# Altium schematic sheet CNCMicaMini.SchDoc
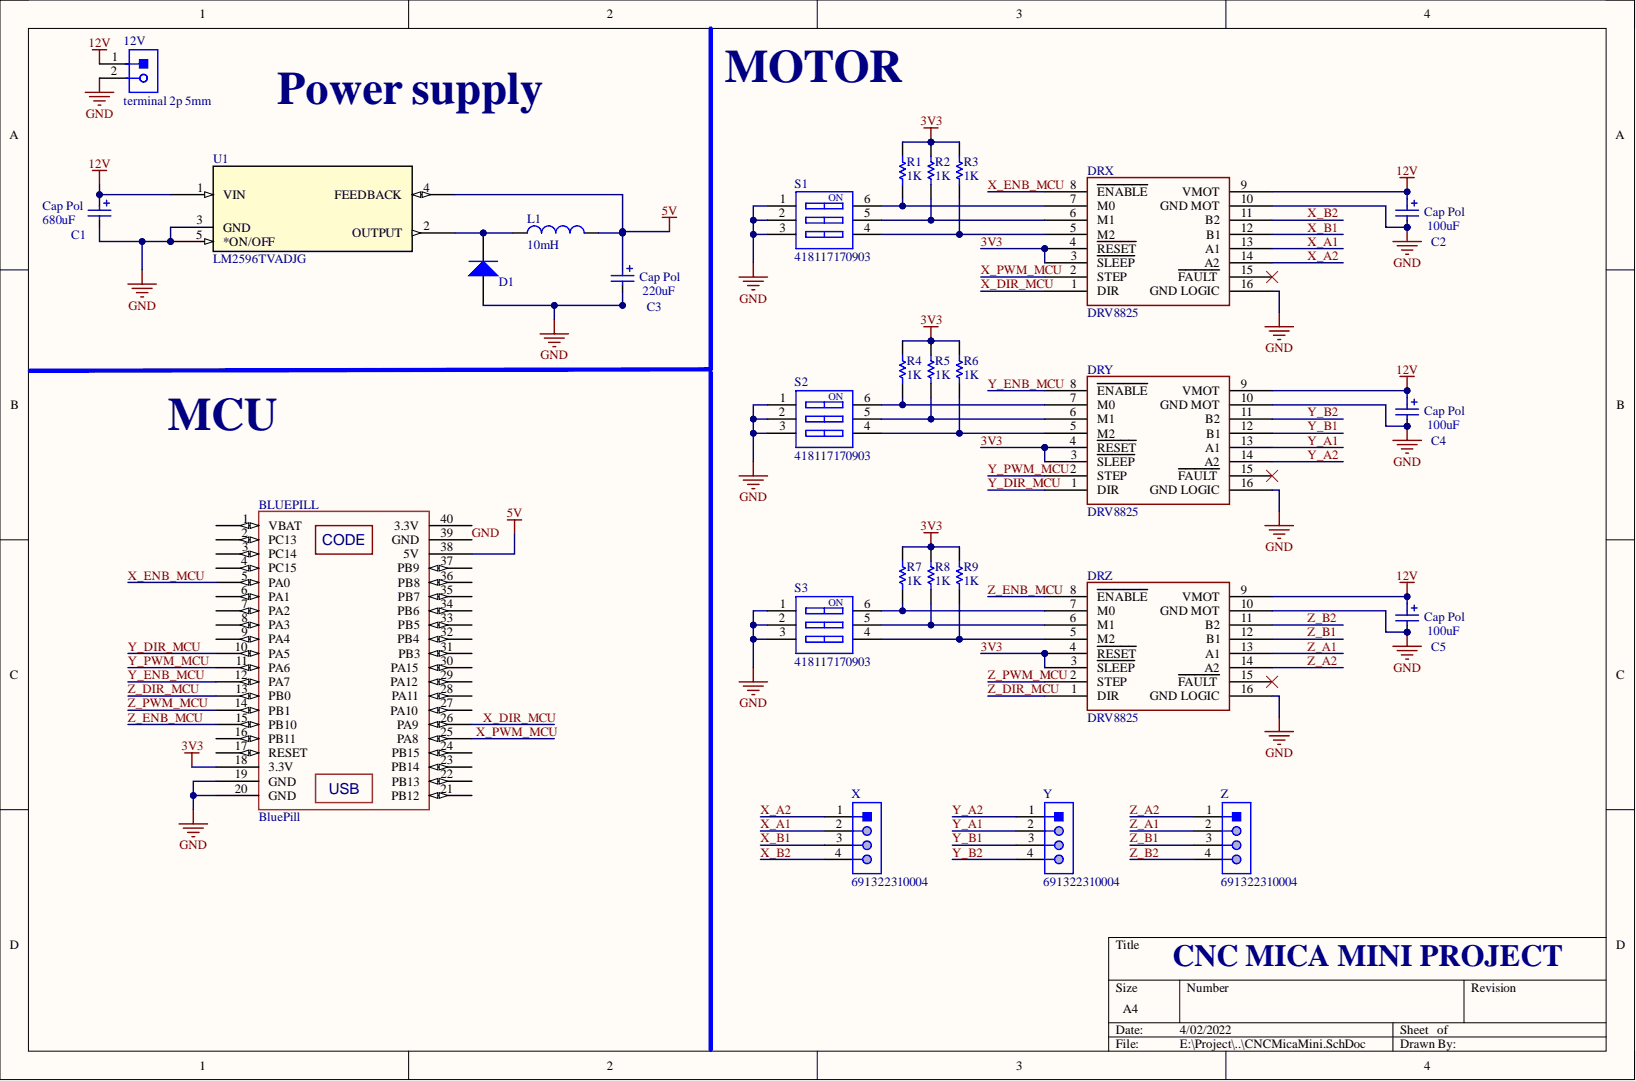
[diagram p0: CNCMicaMini.SchDoc]

## Parts
12V  terminal 2p 5mm  [terminal 2p 5mm]
BLUEPILL  BluePill  [BlUEPILL]
C1  Cap Pol  value 680uF  [CP1/2]
C2  Cap Pol  value 100uF  [CP1/2]
C3  Cap Pol  value 220uF  [CP1/2]
C4  Cap Pol  value 100uF  [CP1/2]
C5  Cap Pol  value 100uF  [CP1/2]
D1  Diode  [D1A]  (value not drawn)
DRX  DRV8825  [DRV8825]
DRY  DRV8825  [DRV8825]
DRZ  DRV8825  [DRV8825]
L1  value 10mH  [INDUCTOR 12.5x12.5]  (hidden comment: Inductor)
R1  value 1K  [RES-SM-0805]  (hidden comment: Res-1K-0805)
R2  value 1K  [RES-SM-0805]  (hidden comment: Res-1K-0805)
R3  value 1K  [RES-SM-0805]  (hidden comment: Res-1K-0805)
R4  value 1K  [RES-SM-0805]  (hidden comment: Res-1K-0805)
R5  value 1K  [RES-SM-0805]  (hidden comment: Res-1K-0805)
R6  value 1K  [RES-SM-0805]  (hidden comment: Res-1K-0805)
R7  value 1K  [RES-SM-0805]  (hidden comment: Res-1K-0805)
R8  value 1K  [RES-SM-0805]  (hidden comment: Res-1K-0805)
R9  value 1K  [RES-SM-0805]  (hidden comment: Res-1K-0805)
S1  418117170903  [418117170903]
S2  418117170903  [418117170903]
S3  418117170903  [418117170903]
U1  LM2596TVADJG  [lm2596]
X  691322310004  [691322310004]
Y  691322310004  [691322310004]
Z  691322310004  [691322310004]

## Nets
5V: BLUEPILL.38(5V) C3.1 L1.2 U1.4(FEEDBACK)
12V: 12V.1 C1.1 C2.1 C4.1 C5.1 DRX.9(VMOT) DRY.9(VMOT) DRZ.9(VMOT) U1.1(VIN)
3V3: BLUEPILL.18(3.3V) DRX.3(SLEEP) DRX.4(RESET) DRY.3(SLEEP) DRY.4(RESET) DRZ.3(SLEEP) DRZ.4(RESET) R1.2 R2.2 R3.2 R4.2 R5.2 R6.2 R7.2 R8.2 R9.2
GND: 12V.2 BLUEPILL.19(GND) BLUEPILL.20(GND) BLUEPILL.39(GND) C1.2 C2.2 C3.2 C4.2 C5.2 D1.1 DRX.10(GND_MOT) DRX.16(GND_LOGIC) DRY.10(GND_MOT) DRY.16(GND_LOGIC) DRZ.10(GND_MOT) DRZ.16(GND_LOGIC) S1.1 S1.2 S1.3 S2.1 S2.2 S2.3 S3.1 S3.2 S3.3 U1.3(GND) U1.5(*ON/OFF)
X_A1: DRX.13(A1) X.2
X_A2: DRX.14(A2) X.1
X_B1: DRX.12(B1) X.3
X_B2: DRX.11(B2) X.4
X_DIR_MCU: BLUEPILL.26(PA9) DRX.1(DIR)
X_ENB_MCU: BLUEPILL.5(PA0) DRX.8(ENABLE)
X_PWM_MCU: BLUEPILL.25(PA8) DRX.2(STEP)
Y_A1: DRY.13(A1) Y.2
Y_A2: DRY.14(A2) Y.1
Y_B1: DRY.12(B1) Y.3
Y_B2: DRY.11(B2) Y.4
Y_DIR_MCU: BLUEPILL.10(PA5) DRY.1(DIR)
Y_ENB_MCU: BLUEPILL.12(PA7) DRY.8(ENABLE)
Y_PWM_MCU: BLUEPILL.11(PA6) DRY.2(STEP)
Z_A1: DRZ.13(A1) Z.2
Z_A2: DRZ.14(A2) Z.1
Z_B1: DRZ.12(B1) Z.3
Z_B2: DRZ.11(B2) Z.4
Z_DIR_MCU: BLUEPILL.13(PB0) DRZ.1(DIR)
Z_ENB_MCU: BLUEPILL.15(PB10) DRZ.8(ENABLE)
Z_PWM_MCU: BLUEPILL.14(PB1) DRZ.2(STEP)
NetD1_2: D1.2 L1.1 U1.2(OUTPUT)
NetDRX_5: DRX.5(M2) R3.1 S1.4
NetDRX_6: DRX.6(M1) R2.1 S1.5
NetDRX_7: DRX.7(M0) R1.1 S1.6
NetDRY_5: DRY.5(M2) R6.1 S2.4
NetDRY_6: DRY.6(M1) R5.1 S2.5
NetDRY_7: DRY.7(M0) R4.1 S2.6
NetDRZ_5: DRZ.5(M2) R9.1 S3.4
NetDRZ_6: DRZ.6(M1) R8.1 S3.5
NetDRZ_7: DRZ.7(M0) R7.1 S3.6

Not connected: BLUEPILL 1(VBAT) 2(PC13) 3(PC14) 4(PC15) 6(PA1) 7(PA2) 8(PA3) 9(PA4) 16(PB11) 17(RESET) 21(PB12) 22(PB13) 23(PB14) 24(PB15) 27(PA10) 28(PA11) 29(PA12) 30(PA15) 31(PB3) 32(PB4) 33(PB5) 34(PB6) 35(PB7) 36(PB8) 37(PB9) 40(3.3V); DRX 15(FAULT); DRY 15(FAULT); DRZ 15(FAULT)

## Library data of the parts
C1-C5 = Cap-TH-Pol: Polarized Capacitor (Radial); Component Kind: Standard; Library Name: capacitor-th.SchLib
D1 = Diode: Default Diode; Component Kind: Standard; Library Name: PIF_Library.intlib
R1-R9 = Res-1K-0805: RES 1K OHM 1% 1/4W 0805; Manufacturer: Stackpole Electronics Inc; Manufacturer Part Number: RNCP0805FTD1K00; Component Kind: Standard; Library Name: resistor-smd.SchLib; Local Supplier: thegioiic; Local Supplier Link: https://www.thegioiic.com/products/dien-tro-1-kohm-0805-5; Supplier 1: Digikey; Supplier 1 Link: https://www.digikey.com/product-detail/en/stackpole-electronics-inc/RNCP0805FTD1K00/RNCP0805FTD1K00CT-ND/2240568; Supplier 1 Part Number: RNCP0805FTD1K00CT-ND
S1-S3 = 418117170903: WS-DITV Compact THT Dip Switch, Flat Actuator, pitch 2.54mm, 3p; Actuator Type: Flat Type; Circuit: SPST-NO; Operation Force: 800g; Pole Number: 3; Rated Current Non-Switching: 100mA; Rated Current Switching: 25mA; Rated Voltage Non-Switching: 50VDC; Rated Voltage Switching: 24VDC; Component Kind: Standard; ComponentLink1Description: Manufacturer; ComponentLink1URL: http://www.we-online.de; ComponentLink2Description: Datasheet; ComponentLink2URL: https://katalog.we-online.com/em/datasheet/418117170903.pdf; Contact Material: Copper Alloy; Contact Plating: Gold; Library Name: WS-DITV.SchLib; Mounting Technology: THT; Operating Temperature: -40 to +85degC; Packaging: Tube; Part Number: 418117170903; Pitch: 2.54mm; Published Date: September 2019; Publisher: Wurth Elektronik
U1 = LM2596TVADJG: No Description Available; Mfr_Name: onsemi; Component Kind: Standard; Manufacturer_Part_Number: LM2596TVADJG; RefDes: RefDes; Type: DEV; Copyright: Copyright (C) 2022 Ultra Librarian. All rights reserved.; Library Name: CNCMicaMini.SchLib
X Y Z = 691322310004: WR-TBL Serie 322 Horizontal PCB Header, Pluggable, pitch 3.81mm, 4p; Compliance: RoHS; Modularity: No; Pin Number: 4; Rated Current [UL]: 10A; Working Voltage [UL]: 300VAC; Application: Pluggable; Component Kind: Standard; ComponentLink1Description: Manufacturer; ComponentLink1URL: http://www.we-online.de; ComponentLink2Description: Datasheet; ComponentLink2URL: https://katalog.we-online.de/em/datasheet/691322310004.pdf; Library Name: WR-TBL_Pluggable PCB Header.SchLib; Mounting Technology: THT; Operating Temperature: -40 to +105degC; Packaging: Box; Part Number: 691322310004; PCB/Cable/Panel: PCB; Pitch: 3.81mm; Published Date: October 2019; Type: Horizontal; Publisher: Wurth Elektronik
12V = terminal 2p 5mm: Component Kind: Standard; Library Name: CNCMicaMini.SchLib
BLUEPILL = BluePill: Component Kind: Standard; Library Name: dev-board.SchLib
DRX DRY DRZ = DRV8825: Component Kind: Standard; Local Supplier: thegioiic; Local Supplier Link: https://www.thegioiic.com/products/stepstick-drv8825-mach-dieu-khien-dong-co-buoc; Library Name: PIFWRobot.SchLib
L1 = Inductor: Component Kind: Standard; Description: Inductor; Library Name: PIF_Library.intlib
